# Altium schematic sheet PAGE5-RTC.SchDoc
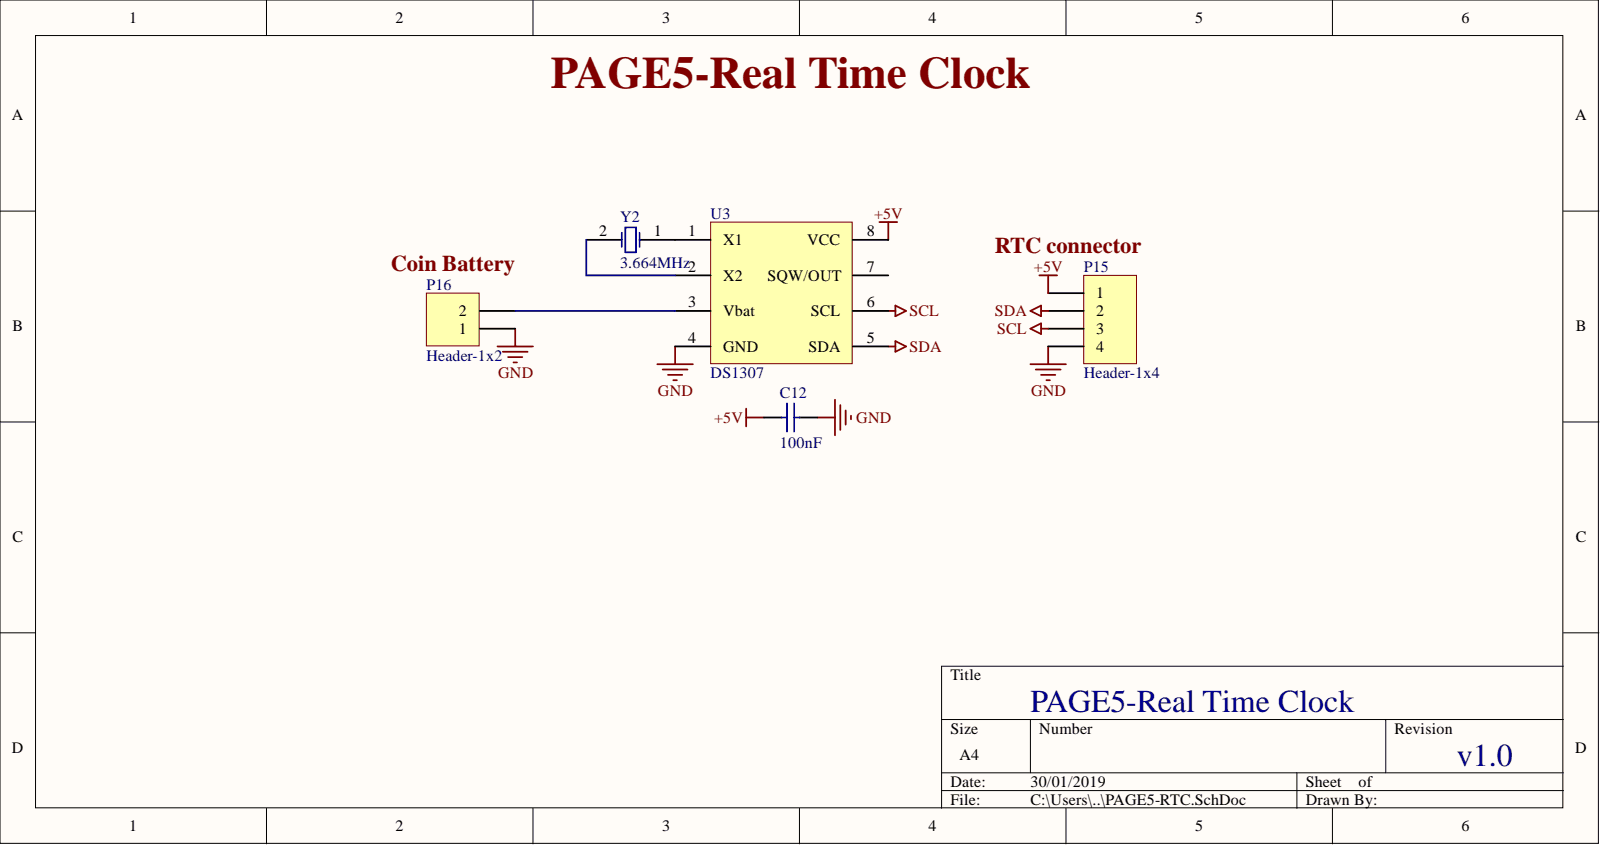
[diagram p0: PAGE5-RTC.SchDoc]

## Parts
C12  value 100nF  [1206_cap]  (hidden comment: cap_smd1206)
P15  Header-1x4  [BrxBeliKon]
P16  Header-1x2  [HDR_1X2]
U3  DS1307  [SOIC_8PIN]
Y2  3.664MHz  [XtalSmd]

## Nets
+5V: C12.2 P15.1 U3.8(VCC)
GND: C12.1 P15.4 P16.1 U3.4(GND)
SCL: P15.3 U3.6(SCL)
SDA: P15.2 U3.5(SDA)
NetP16_2: P16.2 U3.3(Vbat)
NetU3_1: U3.1(X1) Y2.1
NetU3_2: U3.2(X2) Y2.2

Not connected: U3 7(SQW/OUT)

## Library data of the parts
C12 = cap_smd1206: Capacitor; Component Kind: Standard; LatestRevisionDate: 17-Jul-2002; LatestRevisionNote: Re-released for DXP Platform.; PackageReference: RAD-0.3; Published: 8-Jun-2000; Signal Integrity: Cap; Simulation: CAP; Library Name: hepek_lib.SchLib; Publisher: Altium Limited
P15 = BrxBeliKon: Header, 4-Pin; Component Kind: Standard; LatestRevisionDate: 17-Jul-2002; LatestRevisionNote: Re-released for DXP Platform.; Signal Integrity: Connector; Library Name: hepek_lib.SchLib; Publisher: Altium Limited
P16 = header_2: Header, 2-Pin; Component Kind: Standard; LatestRevisionDate: 17-Jul-2002; LatestRevisionNote: Re-released for DXP Platform.; Signal Integrity: Connector; Library Name: hepek_lib.SchLib; Publisher: Altium Limited
Y2 = XtalSmd: Crystal Oscillator; Component Kind: Standard; LatestRevisionDate: 17-Jul-2002; LatestRevisionNote: Re-released for DXP Platform.; PackageReference: R38; Published: 8-Jun-2000; Simulation: XTAL; Library Name: hepek_lib.SchLib; Publisher: Altium Limited
U3 = DS1307: Component Kind: Standard; Library Name: hepek_lib.SchLib
